# Altium schematic sheet Power_Indicators.SchDoc
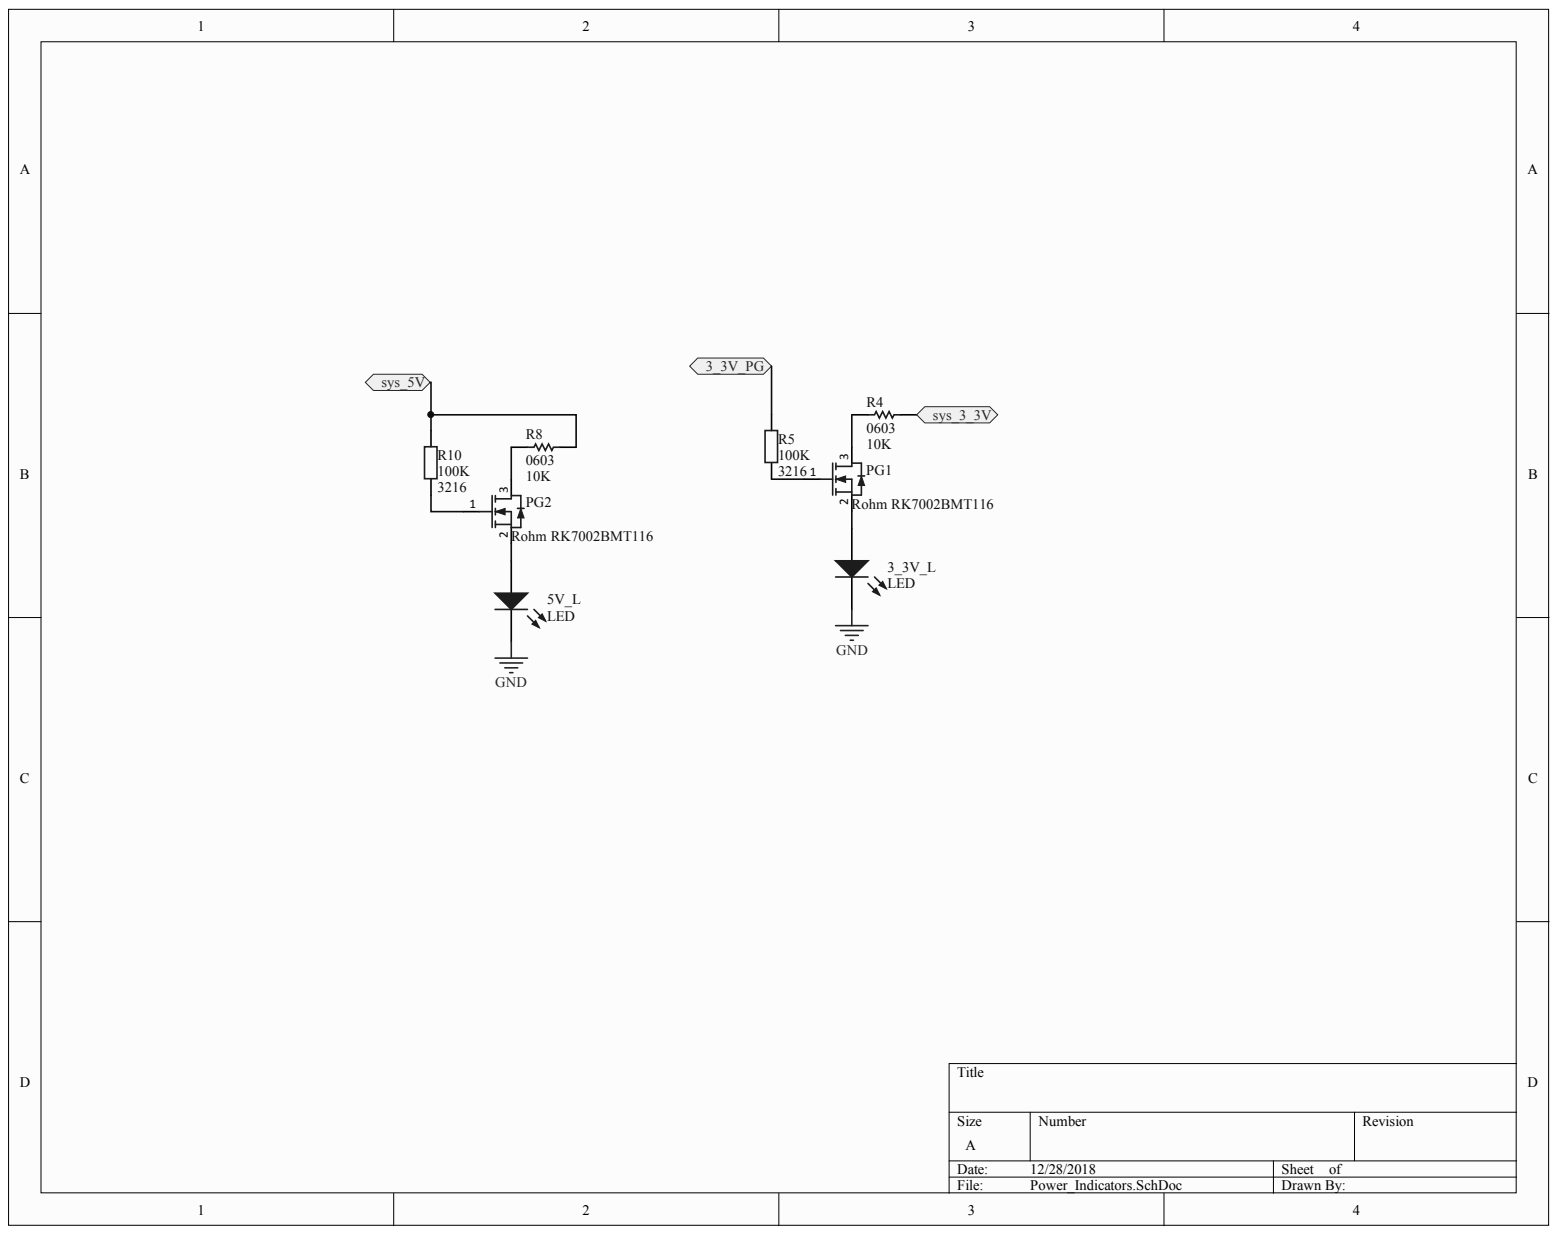
[diagram p0: Power_Indicators.SchDoc]

## Parts
3_3V_L  LED  [PCB-a4kbstwpa15hyka10z5q-1]
5V_L  LED  [PCB-a4kbstwpa15hyka10z5q-1]
PG1  Rohm RK7002BMT116  [PCB-lhick5qix2l1q5xknwuk-1]
PG2  Rohm RK7002BMT116  [PCB-lhick5qix2l1q5xknwuk-1]
R4  Case/Package (SI): 0603  [RESC0603X28X15ML05T05]  (hidden comment: Vishay CRCW02010000Z0ED)
R5  Case/Package: 3216  Resistance: 100K  [CAPC3216X94X50ML20T25]  (hidden comment: Welwyn LRMAM1206-R02FT5)
R8  Case/Package (SI): 0603  [RESC0603X28X15ML05T05]  (hidden comment: Vishay CRCW02010000Z0ED)
R10  Case/Package: 3216  Resistance: 100K  [CAPC3216X94X50ML20T25]  (hidden comment: Welwyn LRMAM1206-R02FT5)

## Ports
3_3V_PG, SYS_3_3V, SYS_5V

## Nets
GND: 3_3V_L.2 5V_L.2
3_3V_PG: R5.1 ; port 3_3V_PG
Net3_3V_L_1: 3_3V_L.1 PG1.2
Net5V_L_1: 5V_L.1 PG2.2
NetPG1_1: PG1.1 R5.2
NetPG1_3: PG1.3 R4.2
NetPG2_1: PG2.1 R10.2
NetPG2_3: PG2.3 R8.2
NetR4_1: R4.1 ; port SYS_3_3V
NetR8_1: R8.1 R10.1 ; port SYS_5V

## Library data of the parts
3_3V_L 5V_L = CMP-afe165a651817026-6: LED; PCB ; Green; 0.63mcd; 3mm; T-1; 7mA; 1.9V; 565nm; 25deg; GaP on GaP; 20V; 20mW; -40; Manufacturer Part Number: TLLG4400; Forward Voltage: 2.40 V; Luminous Intensity: 1.20 mcd; Viewing Angle: 25 °; Color: Green; Component Kind: Standard; Library Name: CommunityVault; Mounting Style: Through Hole, Radial; OctopartID: afe165a651817026; Octopart URL: http://octopart.com/tllg4400-vishay-55433990; Voltage Rating (DC): 2.40 V
PG1 PG2 = CMP-c6120e73f56f19d5-3: N-Channel 60 V 0.2 W Surface Mount 2.5 V Drive Silicon Power Mosfet - SOT-23; Component Kind: Standard; Library Name: CommunityVault; Mounting Style: Surface Mount; Octopart URL: http://octopart.com/rk7002bmt116-rohm-23795227
R4 R8 = CMP-1859241-2: RES SMD 0.0 OHM JUMPER 1/20W; Lead-Free Status: Lead Free; Power Rating: 0.05 W; Resistance: 10K; Resistance Tolerance: ±5%; Voltage Rating (DC): 30.0 V; Case/Package: 0201; Component Kind: Standard; Library Name: CommunityVault; Manufacturer Part Number: CRCW02010000Z0ED; Mounting Style: Surface Mount; Number of Pins: 2; OctopartID: 5e2b95433f4781ec; Octopart Url: https://octopart.com/crcw02010000z0ed-vishay-39994378; REACH SVHC Compliance: No SVHC; RoHS: Compliant; Size-Height: 0.00023 m; Size-Length: 0.0006 m; Size-Width: 0.0003 m
R5 R10 = CMP-c1220a8799fa1ee5-1: Product Range: AEC-Q200 LRMA Series; Power Rating: 1.00 W; Resistance Tolerance: ±1%; Component Kind: Standard; Library Name: CommunityVault; Manufacturer Part Number: LRMAM1206-R02FT5; Mounting Style: Surface Mount; OctopartID: c1220a8799fa1ee5; Octopart URL: http://octopart.com/lrmam1206-r02ft5-welwyn-45055422; Packaging: Cut Tape (CT); REACH SVHC Compliance: No SVHC; RoHS: Compliant
